# Altium schematic sheet MCU.SchDoc(MCU)
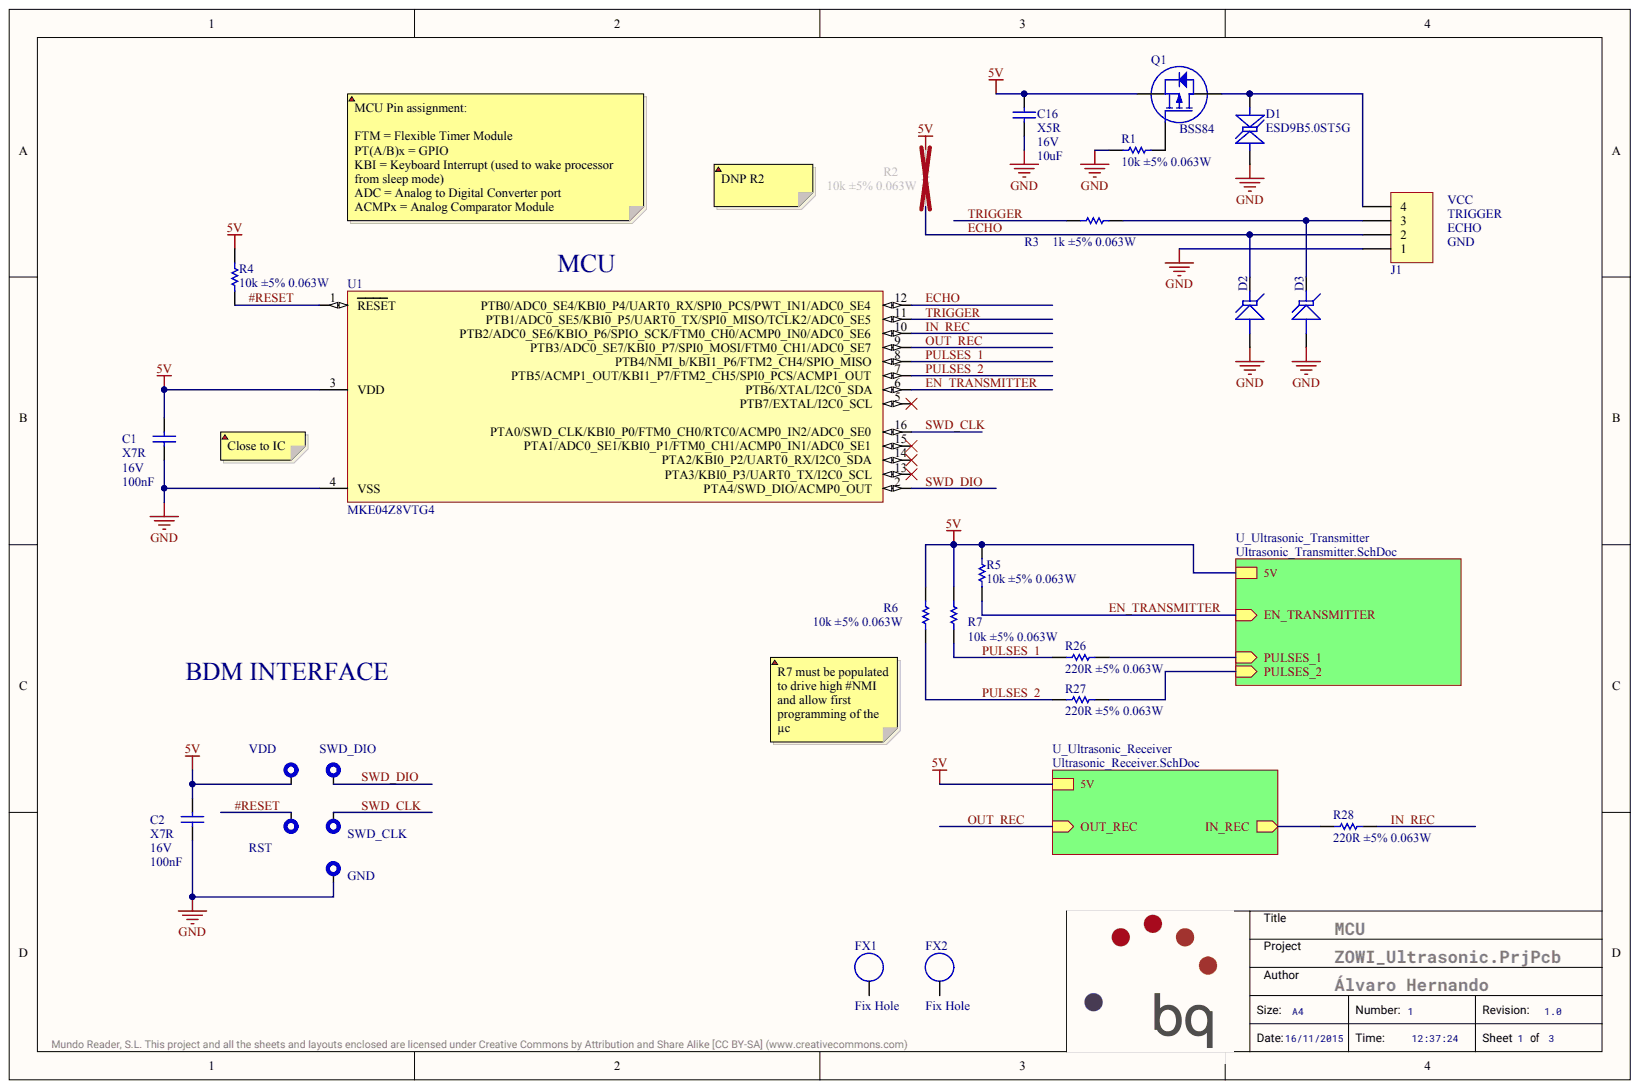
[diagram p0: MCU.SchDoc]

## Parts
C1  Capacitance: 100nF  Dielectric: X7R  Voltage: 16V  [C0402]  (hidden comment: Capacitor)
C2  Capacitance: 100nF  Dielectric: X7R  Voltage: 16V  [C0402]  (hidden comment: Capacitor)
C16  Capacitance: 10uF  Dielectric: X5R  Voltage: 16V  [C0603]  (hidden comment: Capacitor)
D1  ESD9B5.0ST5G  [SOD923]
D2  ESD9L5.0ST5G  [SOD923]  (value not drawn)
D3  ESD9L5.0ST5G  [SOD923]  (value not drawn)
FX1  Fix Hole  [Fix_Hole_3.2mm]  (no BOM)
FX2  Fix Hole  [Fix_Hole_3.2mm]  (no BOM)
GND  Testpoint  [TP_POWER]  (value not drawn)  (no BOM)
J1  value 4  [1x4 MTE Angled Header 2.54mm]  (hidden comment: 1x4 MTE Angled Header 2.54mm)
Q1  BSS84  [SOT23-3]
R1  value 10k ±5% 0.063W  Power: 0.063W  Resistance: 10k  Tolerance: ±5%  [R0402]  (hidden comment: Resistor)
R2  value 10k ±5% 0.063W  Power: 0.063W  [R0402]  (hidden comment: Resistor)
R3  value 1k ±5% 0.063W  Power: 0.063W  Resistance: 1k  Tolerance: ±5%  [R0402]  (hidden comment: Resistor)
R4  value 10k ±5% 0.063W  Resistance: 10k  Tolerance: ±5%  [R0402]  (hidden comment: Resistor)
R5  value 10k ±5% 0.063W  Resistance: 10k  Tolerance: ±5%  [R0402]  (hidden comment: Resistor)
R6  value 10k ±5% 0.063W  Power: 0.063W  [R0402]  (hidden comment: Resistor)
R7  value 10k ±5% 0.063W  Resistance: 10k  [R0402]  (hidden comment: Resistor)
R26  value 220R ±5% 0.063W  Power: 0.063W  Resistance: 220R  Tolerance: ±5%  [R0402]  (hidden comment: Resistor)
R27  value 220R ±5% 0.063W  Power: 0.063W  Resistance: 220R  Tolerance: ±5%  [R0402]  (hidden comment: Resistor)
R28  value 220R ±5% 0.063W  Power: 0.063W  Resistance: 220R  Tolerance: ±5%  [R0402]  (hidden comment: Resistor)
RST  Testpoint  [TP_POWER]  (value not drawn)  (no BOM)
SWD_CLK  Testpoint  [TP_POWER]  (value not drawn)  (no BOM)
SWD_DIO  Testpoint  [TP_POWER]  (value not drawn)  (no BOM)
U1  MKE04Z8VTG4  [TSSOP16]
VDD  Testpoint  [TP_POWER]  (value not drawn)  (no BOM)

## Nets
5V: C1.2 C2.2 C16.2 Q1.2 R2.2 R4.2 R5.2 R6.2 R7.2 U1.3(VDD) VDD.1
GND: C1.1 C2.1 C16.1 D1.A D2.A D3.A GND.1 J1.1 R1.2 U1.4(VSS)
#RESET: R4.1 RST.1 U1.1(RESET)
ECHO: D2.K J1.2 R2.1 U1.12(PTB0/ADC0_SE4/KBI0_P4/UART0_RX/SPI0_PCS/PWT_IN1/ADC0_SE4)
EN_TRANSMITTER: R5.1 U1.6(PTB6/XTAL/I2C0_SDA)
IN_REC: R28.2 U1.10(PTB2/ADC0_SE6/KBIO_P6/SPIO_SCK/FTM0_CH0/ACMP0_IN0/ADC0_SE6)
OUT_REC: U1.9(PTB3/ADC0_SE7/KBI0_P7/SPI0_MOSI/FTM0_CH1/ADC0_SE7)
PULSES_1: R7.1 R26.1 U1.8(PTB4/NMI_b/KBI1_P6/FTM2_CH4/SPIO_MISO)
PULSES_2: R6.1 R27.1 U1.7(PTB5/ACMP1_OUT/KBI1_P7/FTM2_CH5/SPI0_PCS/ACMP1_OUT)
SWD_CLK: SWD_CLK.1 U1.16(PTA0/SWD_CLK/KBI0_P0/FTM0_CH0/RTC0/ACMP0_IN2/ADC0_SE0)
SWD_DIO: SWD_DIO.1 U1.2(PTA4/SWD_DIO/ACMP0_OUT)
TRIGGER: R3.1 U1.11(PTB1/ADC0_SE5/KBI0_P5/UART0_TX/SPI0_MISO/TCLK2/ADC0_SE5)
NetD1_K: D1.K J1.4 Q1.3
NetD3_K: D3.K J1.3 R3.2
NetFX1_1: FX1.1
NetFX2_1: FX2.1
NetQ1_1: Q1.1 R1.1
NetR26_2: R26.2
NetR27_2: R27.2
NetR28_1: R28.1
NetU1_5: U1.5(PTB7/EXTAL/I2C0_SCL)
NetU1_13: U1.13(PTA3/KBI0_P3/UART0_TX/I2C0_SCL)
NetU1_14: U1.14(PTA2/KBI0_P2/UART0_RX/I2C0_SDA)
NetU1_15: U1.15(PTA1/ADC0_SE1/KBI0_P1/FTM0_CH1/ACMP0_IN1/ADC0_SE1)

## Library data of the parts
C1 C2 = C0402_100nF_16V_±10%: Capacitor SMD; Manufacturer: Kemet; Database Table Name: C0402_16V$; OrderingCode: C0402C104K4RAC; Temp_ Max: 125; Temp_ Min: -55; Tolerance: ±10%; Value: 100nF ±10% 16V; Component Kind: Standard; Library Name: Pasives_Capacitor_SMD.SVNDbLib; Part Number: C0402_100nF_16V_±10%
C16 = C0603_10uF_16V_±20%: Capacitor SMD; Manufacturer: Taiyo Yuden; Database Table Name: C0603_16V$; OrderingCode: EMK107BBJ106MA-T; OrderingCode 2: C1608X5R1C106M080AB; Temp_ Max: 85; Temp_ Min: -55; Tolerance: ±20%; Value: 10uF ±20% 16V; Component Kind: Standard; Library Name: Pasives_Capacitor_SMD.SVNDbLib; Manufacturer 2: TDK; Part Number: C0603_10uF_16V_±20%
D1 = TVS 5Vdc Bidir: Protection, Bidirectional Transient Suppression Diodes; Manufacturer: ON Semiconductor; Breakdown Voltage: 5,8Vdc; Database Table Name: TVS$; Overvoltage Current: 1A; Temp_ Max: 150; Temp_ Min: -55; Voltage: 5Vdc; Component Kind: Standard; Library Name: Protection.SVNDbLib; OrderingCode: ESD9B5.0ST5G; Part Number: TVS 5Vdc Bidir
D2 D3 = TVS 5Vdc Low Cap: Protection, Transient Suppression Diode; Manufacturer: ON Semiconductor; Breakdown Voltage: 5,4Vdc; Database Table Name: TVS$; Overvoltage Current: 1A; Temp_ Max: 125; Temp_ Min: -55; Voltage: 5Vdc; Component Kind: Standard; Library Name: Protection.SVNDbLib; OrderingCode: ESD9L5.0ST5G; Part Number: TVS 5Vdc Low Cap
FX1 FX2 = FX_3.2: Database Table Name: Mechanic$; Description: Fix Hole; Part Number: FX_3.2; Library Name: Miscelanea.SVNDbLib
GND RST SWD_CLK SWD_DIO VDD = TP_POWER: Manufacturer: Oxley; Database Table Name: Testpoint$; OrderingCode: SMOX/060/B1; SAP: 303552; Description: Testpoint; Part Number: TP_POWER; Library Name: Miscelanea.SVNDbLib
J1 = CON_MTE_Angled_HDR_THT2.54_4: Connector, 1x4 MTE Angled Header 2.54mm; Manufacturer: TE Connectivity; Database Table Name: Con_MTE_Angled_HDR_THT_2#54$; OrderingCode: 5-103635-3; Temp_ Max: 105; Temp_ Min: -65; Component Kind: Standard; Library Name: Conectores_MTE_Header_2.54.SVNDbLib; Part Number: CON_MTE_Angled_HDR_THT2.54_4; Pitch: 2.54mm
Q1 = BSS84: PFET; Manufacturer: Fairchild Semiconductor; Database Table Name: MOSFET$; Id: 0,13A; Temp_ Max: 150; Temp_ Min: -55; Vds: 50V; Vgss: 20V; Component Kind: Standard; Library Name: Semiconductors_Transistors.SVNDbLib; OrderingCode: BSS84; Part Number: BSS84
R1 = R0402_10k_0.063W_±5%: Resistor, SMD; Manufacturer: Vishay; Database Table Name: R0402_5%$; OrderingCode: CRCW040210K0JN; Temp_ Max: 155; Temp_ Min: -55; Component Kind: Standard; Library Name: Pasives_Resistor_SMD.SVNDbLib; PackageDescription: SMD; Part Number: R0402_10k_0.063W_±5%
R2 R6 = R0402_10k_0.063W_±5%: Resistor, SMD; Manufacturer: Vishay; Database Table Name: R0402_5%$; OrderingCode: CRCW040210K0JN; Resistance: 10k; Temp_ Max: 155; Temp_ Min: -55; Tolerance: ±5%; Component Kind: Standard; Library Name: Pasives_Resistor_SMD.SVNDbLib; PackageDescription: SMD; Part Number: R0402_10k_0.063W_±5%
R3 = R0402_1k_0.063W_±5%: Resistor, SMD; Manufacturer: Vishay; Database Table Name: R0402_5%$; OrderingCode: CRCW04021K00JN; Temp_ Max: 155; Temp_ Min: -55; Component Kind: Standard; Library Name: Pasives_Resistor_SMD.SVNDbLib; PackageDescription: SMD; Part Number: R0402_1k_0.063W_±5%
R4 = R0402_10k_0.063W_±5%: Resistor, SMD; Manufacturer: Vishay; Database Table Name: R0402_5%$; OrderingCode: CRCW040210K0JN; Power: 0.063W; Temp_ Max: 155; Temp_ Min: -55; Value: 10k ±5% 0.063W; Component Kind: Standard; Library Name: Pasives_Resistor_SMD.SVNDbLib; PackageDescription: SMD; Part Number: R0402_10k_0.063W_±5%
R5 = R0402_10k_0.063W_±5%: Resistor, SMD; Manufacturer: Vishay; Database Table Name: R0402_5%$; OrderingCode: CRCW040210K0JN; Power: 0.063W; Temp_ Max: 155; Temp_ Min: -55; Component Kind: Standard; Library Name: Pasives_Resistor_SMD.SVNDbLib; PackageDescription: SMD; Part Number: R0402_10k_0.063W_±5%
R7 = R0402_10k_0.063W_±5%: Resistor, SMD; Manufacturer: Vishay; Database Table Name: R0402_5%$; OrderingCode: CRCW040210K0JN; Power: 0.063W; Temp_ Max: 155; Temp_ Min: -55; Tolerance: ±5%; Value: 10k ±5% 0.063W; Component Kind: Standard; Library Name: Pasives_Resistor_SMD.SVNDbLib; PackageDescription: SMD; Part Number: R0402_10k_0.063W_±5%
R26-R28 = R0402_220R_0.063W_±5%: Resistor, SMD; Manufacturer: Vishay; Database Table Name: R0402_5%$; OrderingCode: CRCW0402220RJN; Temp_ Max: 155; Temp_ Min: -55; Component Kind: Standard; Library Name: Pasives_Resistor_SMD.SVNDbLib; PackageDescription: SMD; Part Number: R0402_220R_0.063W_±5%
U1 = MKE04Z8VTG4: Processor, 32-bit microcontroller; Manufacturer: Freescale; Database Table Name: Freescale$; Temp_ Max: 105; Temp_ Min: -40; Component Kind: Standard; Library Name: Processor.SVNDbLib; OrderingCode: MKE04Z8VTG4; Part Number: MKE04Z8VTG4
